# Altium schematic sheet MD0043  SD卡读写模块 SPI接口 单片机 Arduino.SchDoc
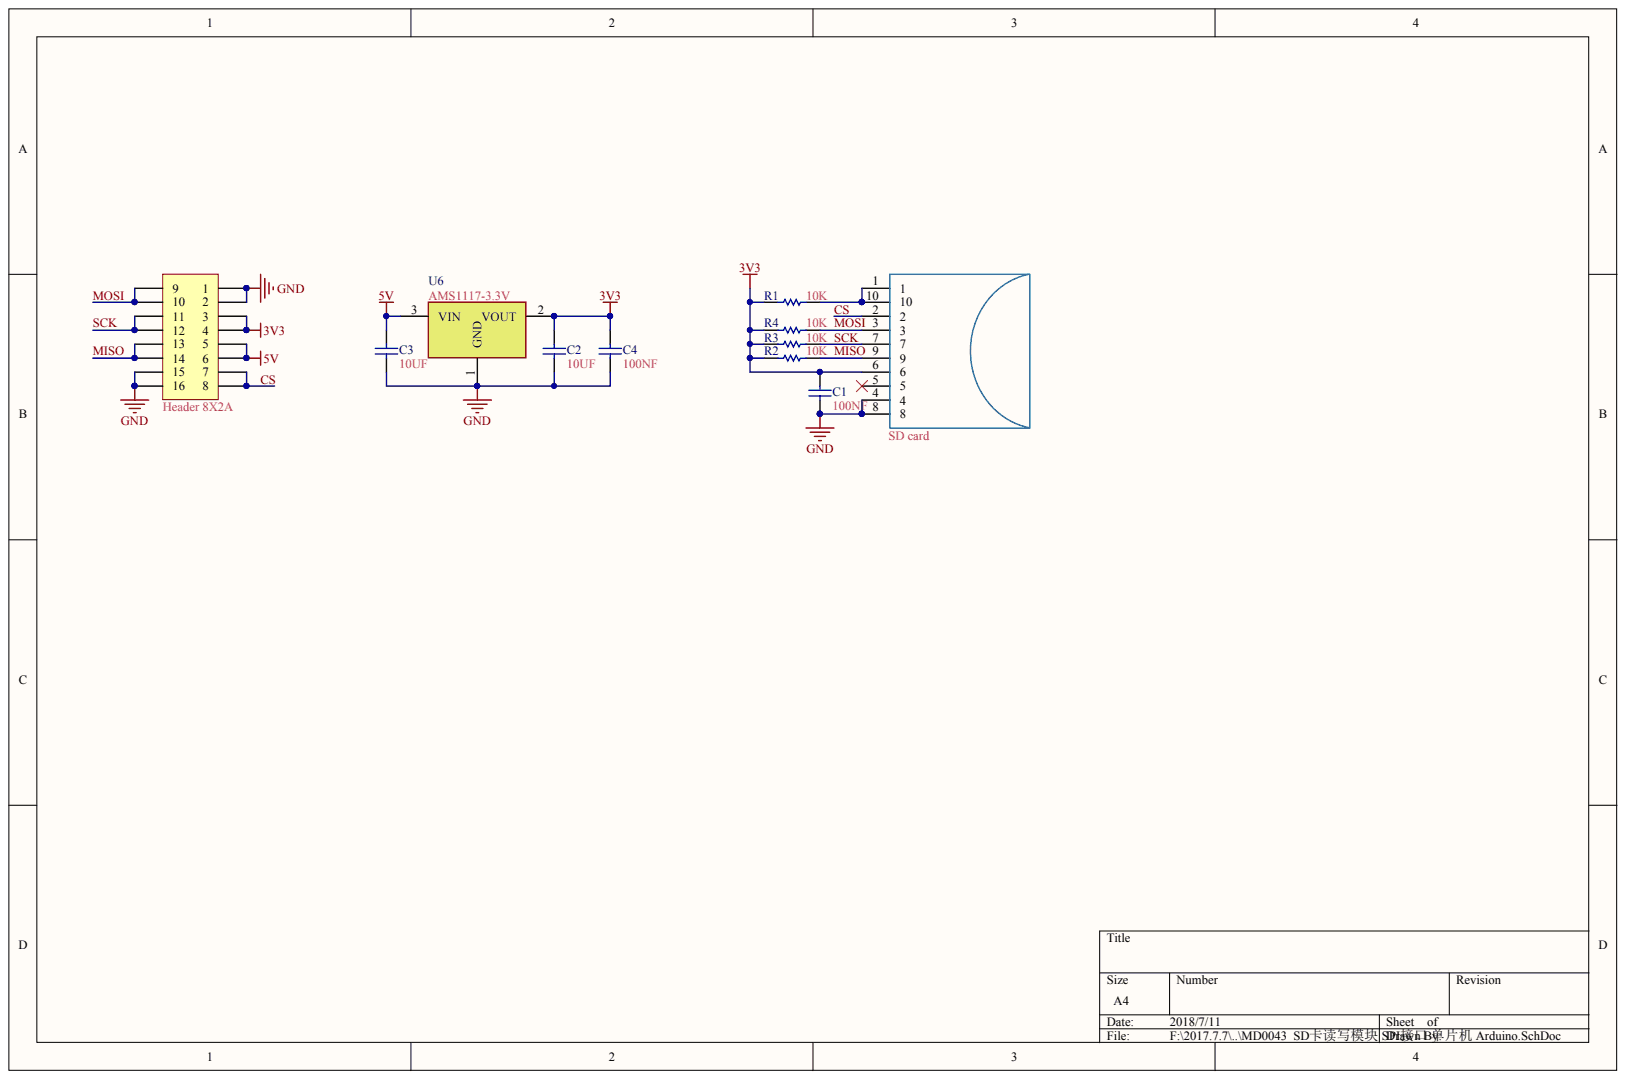
[diagram p0: MD0043  SD卡读写模块 SPI接口 单片机 Arduino.SchDoc]

## Parts
C1  value 100NF  (hidden comment: Cap)
C2  value 10UF  (hidden comment: Cap)
C3  value 10UF  (hidden comment: Cap)
C4  value 100NF  (hidden comment: Cap)
R1  10K
R2  10K
R3  10K
R4  10K
U6  AMS1117-3.3V

  Header 8X2A

## Nets
3V3: R1.1 R2.1 R3.2 R4.2 .3 .4
5V: .5 .6
GND: .1 .2 .15 .16
Net_1: R1.2 .1 .10
Net_4: .4
Net_5: .5
Net_6: .6
Net_8: .8
CS: .2 .7 .8
MISO: .13 .14
MOSI: R2.2 R4.1 .3 .9 .10
SCK: R3.1 .7 .11 .12

Not connected: C1 1 2; C2 1 2; C3 1 2; C4 1 2; U6 1 2 3

## Library data of the parts
C1-C4 R1-R4 U6  = (no symbol name)
  C1-C4: Published: 8-Jun-2000; LatestRevisionDate: 17-Jul-2002; LatestRevisionNote: Re-released for DXP Platform.; PackageReference: RAD-0.3; PackageDescription: Radial Cap, Thru-Hole; 2 Leads; 0.3 in Pin Spacing
  R1-R4: Published: 8-Jun-2000; LatestRevisionDate: 17-Jul-2002; LatestRevisionNote: Re-released for DXP Platform.; PackageReference: AXIAL-0.3; PackageDescription: Axial Device, Thru-Hole; 2 Leads; 0.3 in Pin Spacing; Value: 10K
  : LatestRevisionDate: 17-Jul-2002; LatestRevisionNote: Re-released for DXP Platform.
  C1-C4 R1-R4 : Publisher: Altium Limited
